# Altium schematic sheet FTDI ToolKit FTDI & USB.SchDoc("FTDI ToolKit FTDI & USB")
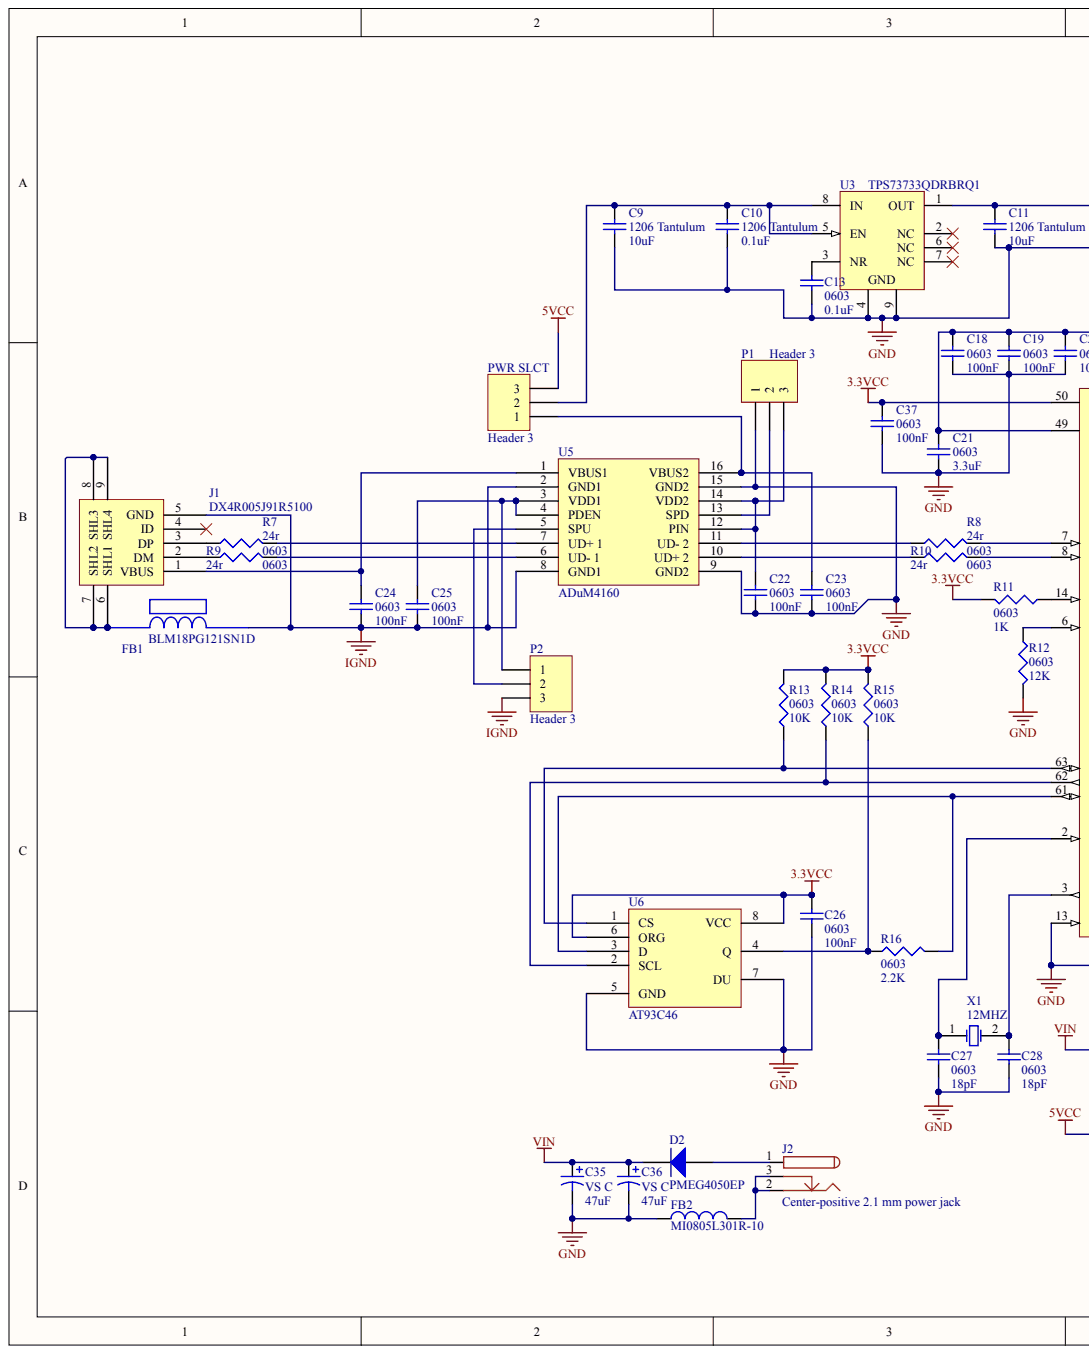
[diagram p0: FTDI ToolKit FTDI & USB.SchDoc, left]
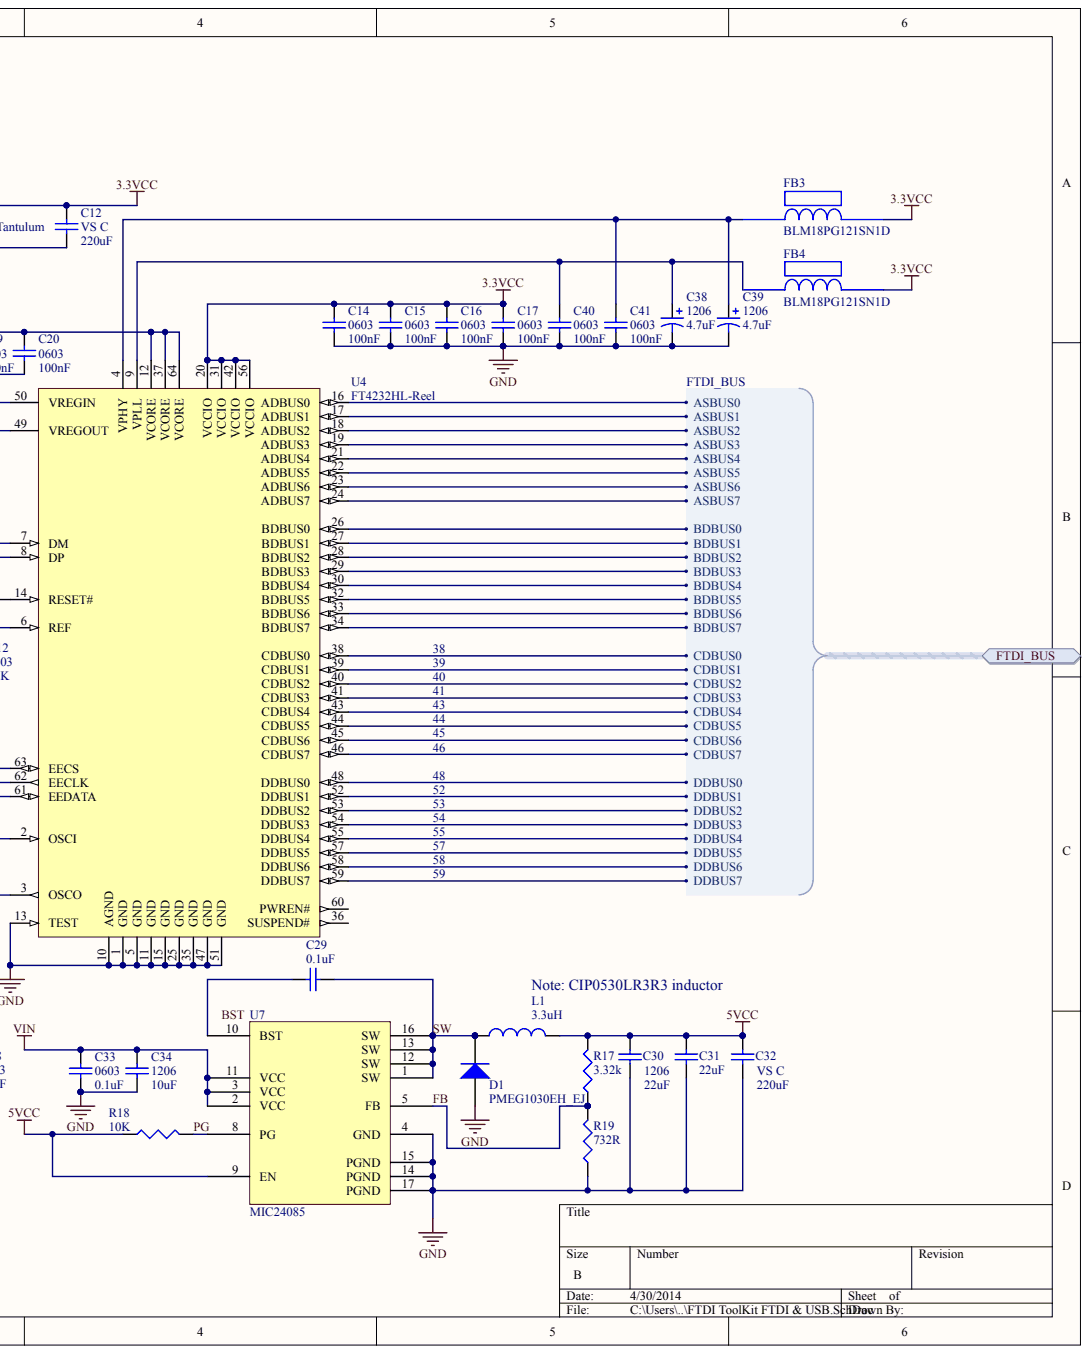
[diagram p1: FTDI ToolKit FTDI & USB.SchDoc, right]

## Parts
C9  1206 Tantulum  value 10uF
C10  1206 Tantulum  value 0.1uF
C11  1206 Tantulum  value 10uF
C12  VS C  value 220uF
C13  0603  value 0.1uF
C14  0603  value 100nF
C15  0603  value 100nF
C16  0603  value 100nF
C17  0603  value 100nF
C18  0603  value 100nF
C19  0603  value 100nF
C20  0603  value 100nF
C21  0603  value 3.3uF
C22  0603  value 100nF
C23  0603  value 100nF
C24  0603  value 100nF
C25  0603  value 100nF
C26  0603  value 100nF
C27  0603  value 18pF
C28  0603  value 18pF
C29  value 0.1uF  (hidden comment: Cap Semi)
C30  1206  value 22uF
C31  value 22uF  (hidden comment: Cap Semi)
C32  VS C  value 220uF
C33  0603  value 0.1uF
C34  1206  value 10uF
C35  VS C  value 47uF
C36  VS C  value 47uF
C37  0603  value 100nF
C38  1206  value 4.7uF
C39  1206  value 4.7uF
C40  0603  value 100nF
C41  0603  value 100nF
D1  PMEG1030EH_EJ
D2  PMEG4050EP
FB1  BLM18PG121SN1D
FB2  MI0805L301R-10
FB3  BLM18PG121SN1D
FB4  BLM18PG121SN1D
J1  DX4R005J91R5100
J2  Center-positive 2.1 mm power jack
L1  value 3.3uH  (hidden comment: Inductor)
P1  Header 3
P2  Header 3
PWR_SLCT  Header 3
R7  0603  value 24r
R8  0603  value 24r
R9  0603  value 24r
R10  0603  value 24r
R11  0603  value 1K
R12  0603  value 12K
R13  0603  value 10K
R14  0603  value 10K
R15  0603  value 10K
R16  0603  value 2.2K
R17  value 3.32k  (hidden comment: Res3)
R18  value 10K  (hidden comment: Res3)
R19  value 732R  (hidden comment: Res3)
U3  TPS73733QDRBRQ1
U4  FT4232HL-Reel
U5  ADuM4160
U6  AT93C46
U7  MIC24085
X1  12MHZ

## Ports
FTDI_BUS, FTDI_BUS.ASBUS0, FTDI_BUS.ASBUS1, FTDI_BUS.ASBUS2, FTDI_BUS.ASBUS3, FTDI_BUS.ASBUS4, FTDI_BUS.ASBUS5, FTDI_BUS.ASBUS6, FTDI_BUS.ASBUS7, FTDI_BUS.BDBUS0, FTDI_BUS.BDBUS1, FTDI_BUS.BDBUS2, FTDI_BUS.BDBUS3, FTDI_BUS.BDBUS4, FTDI_BUS.BDBUS5, FTDI_BUS.BDBUS6, FTDI_BUS.BDBUS7, FTDI_BUS.CDBUS0, FTDI_BUS.CDBUS1, FTDI_BUS.CDBUS2, FTDI_BUS.CDBUS3, FTDI_BUS.CDBUS4, FTDI_BUS.CDBUS5, FTDI_BUS.CDBUS6, FTDI_BUS.CDBUS7, FTDI_BUS.DDBUS0, FTDI_BUS.DDBUS1, FTDI_BUS.DDBUS2, FTDI_BUS.DDBUS3, FTDI_BUS.DDBUS4, FTDI_BUS.DDBUS5, FTDI_BUS.DDBUS6, FTDI_BUS.DDBUS7

## Nets
3.3VCC: C11.1 C12.1 C14.2 C15.2 C16.2 C17.2 C26.2 C37.2 FB3.2 FB4.2 R11.2 R13.2 R14.2 R15.2 U3.1(OUT) U4.20(VCCIO) U4.31(VCCIO) U4.42(VCCIO) U4.50(VREGIN) U4.56(VCCIO) U6.6(ORG) U6.8(VCC)
5VCC: C30.2 C31.1 C32.1 L1.2 PWR_SLCT.3 R17.2 R18.1 U7.9(EN)
GND: C9.2 C10.1 C11.2 C12.2 C13.1 C14.1 C15.1 C16.1 C17.1 C18.1 C19.1 C20.1 C21.1 C22.1 C23.1 C26.1 C27.1 C28.1 C30.1 C31.2 C32.2 C33.1 C34.1 C35.2 C36.2 C37.1 C38.2 C39.2 C40.1 C41.1 D1.1 FB2.1 P1.1 R12.2 R19.1 U3.4 U3.9 U4.1(GND) U4.5(GND) U4.10(AGND) U4.11(GND) U4.13(TEST) U4.15(GND) U4.25(GND) U4.35(GND) U4.47(GND) U4.51(GND) U5.9(GND2) U5.15(GND2) U6.5(GND) U6.7(DU) U7.4(GND) U7.14(PGND) U7.15(PGND) U7.17(PGND)
IGND: C24.1 C25.1 FB1.2 J1.5(GND) P2.3 U5.2(GND1) U5.8(GND1)
VIN: C33.2 C34.2 C35.1 C36.1 D2.1 U7.2(VCC) U7.3(VCC) U7.11(VCC)
FB: R17.1 R19.2 U7.5(FB)
PG: R18.2 U7.8(PG)
SW: C29.1 D1.2 L1.1 U7.1(SW) U7.12(SW) U7.13(SW) U7.16(SW)
NetC9_1: C9.1 C10.2 PWR_SLCT.2 U3.5(EN) U3.8(IN)
NetC13_2: C13.2 U3.3(NR)
NetC18_2: C18.2 C19.2 C20.2 C21.2 U4.12(VCORE) U4.37(VCORE) U4.49(VREGOUT) U4.64(VCORE)
NetC22_2: C22.2 P1.3 U5.12(PIN) U5.14(VDD2)
NetC23_2: C23.2 PWR_SLCT.1 U5.16(VBUS2)
NetC24_2: C24.2 J1.1(VBUS) U5.1(VBUS1)
NetC25_2: C25.2 P2.1 U5.3(VDD1) U5.4(PDEN)
NetC27_2: C27.2 U4.2(OSCI) X1.1
NetC28_2: C28.2 U4.3(OSCO) X1.2
NetC29_2: C29.2 U7.10(BST)
NetC38_1: C38.1 C40.2 FB4.1 U4.9(VPLL)
NetC39_1: C39.1 C41.2 FB3.1 U4.4(VPHY)
NetD2_2: D2.2 J2.1
NetFB1_1: FB1.1 J1.6(SHL1) J1.7(SHL2) J1.8(SHL3) J1.9(SHL4)
NetFB2_2: FB2.2 J2.2 J2.3
NetJ1_2: J1.2(DM) R9.1
NetJ1_3: J1.3(DP) R7.1
NetP1_2: P1.2 U5.13(SPD)
NetP2_2: P2.2 U5.5(SPU)
NetR7_2: R7.2 U5.7(UD+_1)
NetR8_1: R8.1 U5.11(UD-_2)
NetR8_2: R8.2 U4.7(DM)
NetR9_2: R9.2 U5.6(UD-_1)
NetR10_1: R10.1 U5.10(UD+_2)
NetR10_2: R10.2 U4.8(DP)
NetR11_1: R11.1 U4.14(RESET#)
NetR12_1: R12.1 U4.6(REF)
NetR13_1: R13.1 U4.63(EECS) U6.1(CS)
NetR14_1: R14.1 U4.62(EECLK) U6.2(SCL)
NetR15_1: R15.1 R16.1 U6.4(Q)
NetR16_2: R16.2 U4.61(EEDATA) U6.3(D)
NetU4_16: U4.16(ADBUS0) ; port FTDI_BUS
NetU4_17: U4.17(ADBUS1) ; port FTDI_BUS
NetU4_18: U4.18(ADBUS2) ; port FTDI_BUS
NetU4_19: U4.19(ADBUS3) ; port FTDI_BUS
NetU4_21: U4.21(ADBUS4) ; port FTDI_BUS
NetU4_22: U4.22(ADBUS5) ; port FTDI_BUS
NetU4_23: U4.23(ADBUS6) ; port FTDI_BUS
NetU4_24: U4.24(ADBUS7) ; port FTDI_BUS
NetU4_26: U4.26(BDBUS0) ; port FTDI_BUS
NetU4_27: U4.27(BDBUS1) ; port FTDI_BUS
NetU4_28: U4.28(BDBUS2) ; port FTDI_BUS
NetU4_29: U4.29(BDBUS3) ; port FTDI_BUS
NetU4_30: U4.30(BDBUS4) ; port FTDI_BUS
NetU4_32: U4.32(BDBUS5) ; port FTDI_BUS
NetU4_33: U4.33(BDBUS6) ; port FTDI_BUS
NetU4_34: U4.34(BDBUS7) ; port FTDI_BUS
NetU4_38: U4.38(CDBUS0) ; port FTDI_BUS
NetU4_39: U4.39(CDBUS1) ; port FTDI_BUS
NetU4_40: U4.40(CDBUS2) ; port FTDI_BUS
NetU4_41: U4.41(CDBUS3) ; port FTDI_BUS
NetU4_43: U4.43(CDBUS4) ; port FTDI_BUS
NetU4_44: U4.44(CDBUS5) ; port FTDI_BUS
NetU4_45: U4.45(CDBUS6) ; port FTDI_BUS
NetU4_46: U4.46(CDBUS7) ; port FTDI_BUS
NetU4_48: U4.48(DDBUS0) ; port FTDI_BUS
NetU4_52: U4.52(DDBUS1) ; port FTDI_BUS
NetU4_53: U4.53(DDBUS2) ; port FTDI_BUS
NetU4_54: U4.54(DDBUS3) ; port FTDI_BUS
NetU4_55: U4.55(DDBUS4) ; port FTDI_BUS
NetU4_57: U4.57(DDBUS5) ; port FTDI_BUS
NetU4_58: U4.58(DDBUS6) ; port FTDI_BUS
NetU4_59: U4.59(DDBUS7) ; port FTDI_BUS
BST:

Not connected: J1 4(ID); U3 2(NC) 6(NC) 7(NC); U4 36(SUSPEND#) 60(PWREN#)

## Library data of the parts
FB2 L1 = (no symbol name): Code_IEC: 1005; Published: 8-Jun-2000; PackageReference: 0402-A; Code_JEITA: 0402; Publisher: Altium Limited
  FB2: LatestRevisionDate: 17-Jul-2002; LatestRevisionNote: Re-released for DXP Platform.
  L1: LatestRevisionDate: 29-May-2009; LatestRevisionNote: IPC-7351 Footprint Added.; PackageDescription: Chip Inductor
R11 = (no symbol name): Value: 1K; Published: 8-Jun-2000; LatestRevisionDate: 29-May-2009; LatestRevisionNote: IPC-7351 Footprint Added.; PackageReference: J1-0603; PackageDescription: Chip Resistor; Publisher: Altium Limited
U3 = (no symbol name): Manufacturer: Texas Instruments; Accuracy(%): 3; Eco-Plan: Green (RoHS & no Sb/Br); Fixed Output Options(V): 3.3; Iout(Max)(A): 1; Iq(Typ)(mA): 0.3; Rating: Automotive; SubFamily: Single Channel LDO; Vdo(Typ)(mV): 130; Vin(Max)(V): 5.5; Vin(Min)(V): 2.2; DatasheetVersion: SBVS123; PackageVersion: 4203482-2/K; PackageReference: DRB8-1750X1500TP; PackageDescription: 8-Pin Plastic Small Outline, Body 3 x 3 mm, Pitch 0.65 mm; Supplier 1: Digi-Key; Supplier Part Number 1: 296-24157-2-ND; Supplier 2: Digi-Key; Supplier Part Number 2: 296-24157-1-ND; Supplier 3: Digi-Key; Supplier Part Number 3: 296-24157-6-ND; Supplier 4: Newark; Supplier Part Number 4: 56P3118; Supplier 5: Mouser; Supplier Part Number 5: 595-TPS73733QDRBRQ1; Vout Adj(Max)(V): 3.3; Vout Adj(Min)(V): 3.3; Mounting Technology: Surface Mount; PartNumber: TPS73733QDRBRQ1; ComponentLink1Description: Manufacturer URL; ComponentLink1URL: http://www.ti.com/; ComponentLink2Description: Datasheet; ComponentLink2URL: http://www.ti.com/general/docs/lit/getliterature.tsp?genericPartNumber=TPS73733-Q1&fileType=pdf; ComponentLink3Description: Package Specification; ComponentLink3URL: http://www.ti.com/litv/pdf/mpds118h
U4 = (no symbol name): Manufacturer: FTDI; DatasheetVersion: ver 2.2, Jan-2012; PackageVersion: Jan-12; PackageReference: LQFP-64; PackageDescription: 64-Pin Thin Quad Flat Pack, 10 x 10 x 1.4 mm Body, 0.5 mm Pitch; PartNumber: FT4232HL-Reel; ComponentLink1Description: Manufacturer URL; ComponentLink1URL: http://www.ftdichip.com/; ComponentLink2Description: Datasheet; ComponentLink2URL: http://www.ftdichip.com/Support/Documents/DataSheets/ICs/DS_FT4232H.pdf
C9-C41 D1 D2 FB1 FB3 FB4 J1 J2 P1 P2 PWR_SLCT R7-R10 R12-R19 U5-U7 X1 = (no symbol name)
  C9-C34 C37 C40 C41: Published: 8-Jun-2000; LatestRevisionDate: 29-May-2009; LatestRevisionNote: IPC-7351 Footprint Added.; PackageReference: C1206; PackageDescription: Chip Capacitor
  C35 C36 C38 C39: Published: 8-Jun-2000; LatestRevisionDate: 29-May-2009; LatestRevisionNote: IPC-7351 Footprint Added.; PackageReference: C0805; PackageDescription: Chip Capacitor
  D1 D2: Published: 8-Jun-2000; LatestRevisionDate: 17-Jul-2002; LatestRevisionNote: Re-released for DXP Platform.; PackageVersion: Sep-1996; PackageReference: SMC; PackageDescription: DO-214-AB/SMC; 2 C-Bend Leads; Body 7.9 x 5.9 mm, inc. leads (LxW); Code_JEDEC: DO-214-AB
  J2: Published: 17-Dec-2003; DatasheetDocument: 09-May-2002; ComponentLink1Description: Manufacturer Link; ComponentLink1URL: http://domino.kycon.com/internet/Products.html
  P1 P2 PWR_SLCT: LatestRevisionDate: 17-Jul-2002; LatestRevisionNote: Re-released for DXP Platform.
  R7-R10 R12-R19: Published: 8-Jun-2000; LatestRevisionDate: 29-May-2009; LatestRevisionNote: IPC-7351 Footprint Added.; PackageReference: J1-0603; PackageDescription: Chip Resistor
  X1: Published: 8-Jun-2000; LatestRevisionDate: 17-Jul-2002; LatestRevisionNote: Re-released for DXP Platform.; PackageReference: R38; PackageDescription: Crystal, Thru-Hole; 2 Leads; Body 3.1 x 8.2 mm (Dia.xH)
  C9-C41 D1 D2 J2 P1 P2 PWR_SLCT R7-R10 R12-R19 X1: Publisher: Altium Limited
